# Altium schematic sheet Brush_Control_V2.0.0.SchDoc
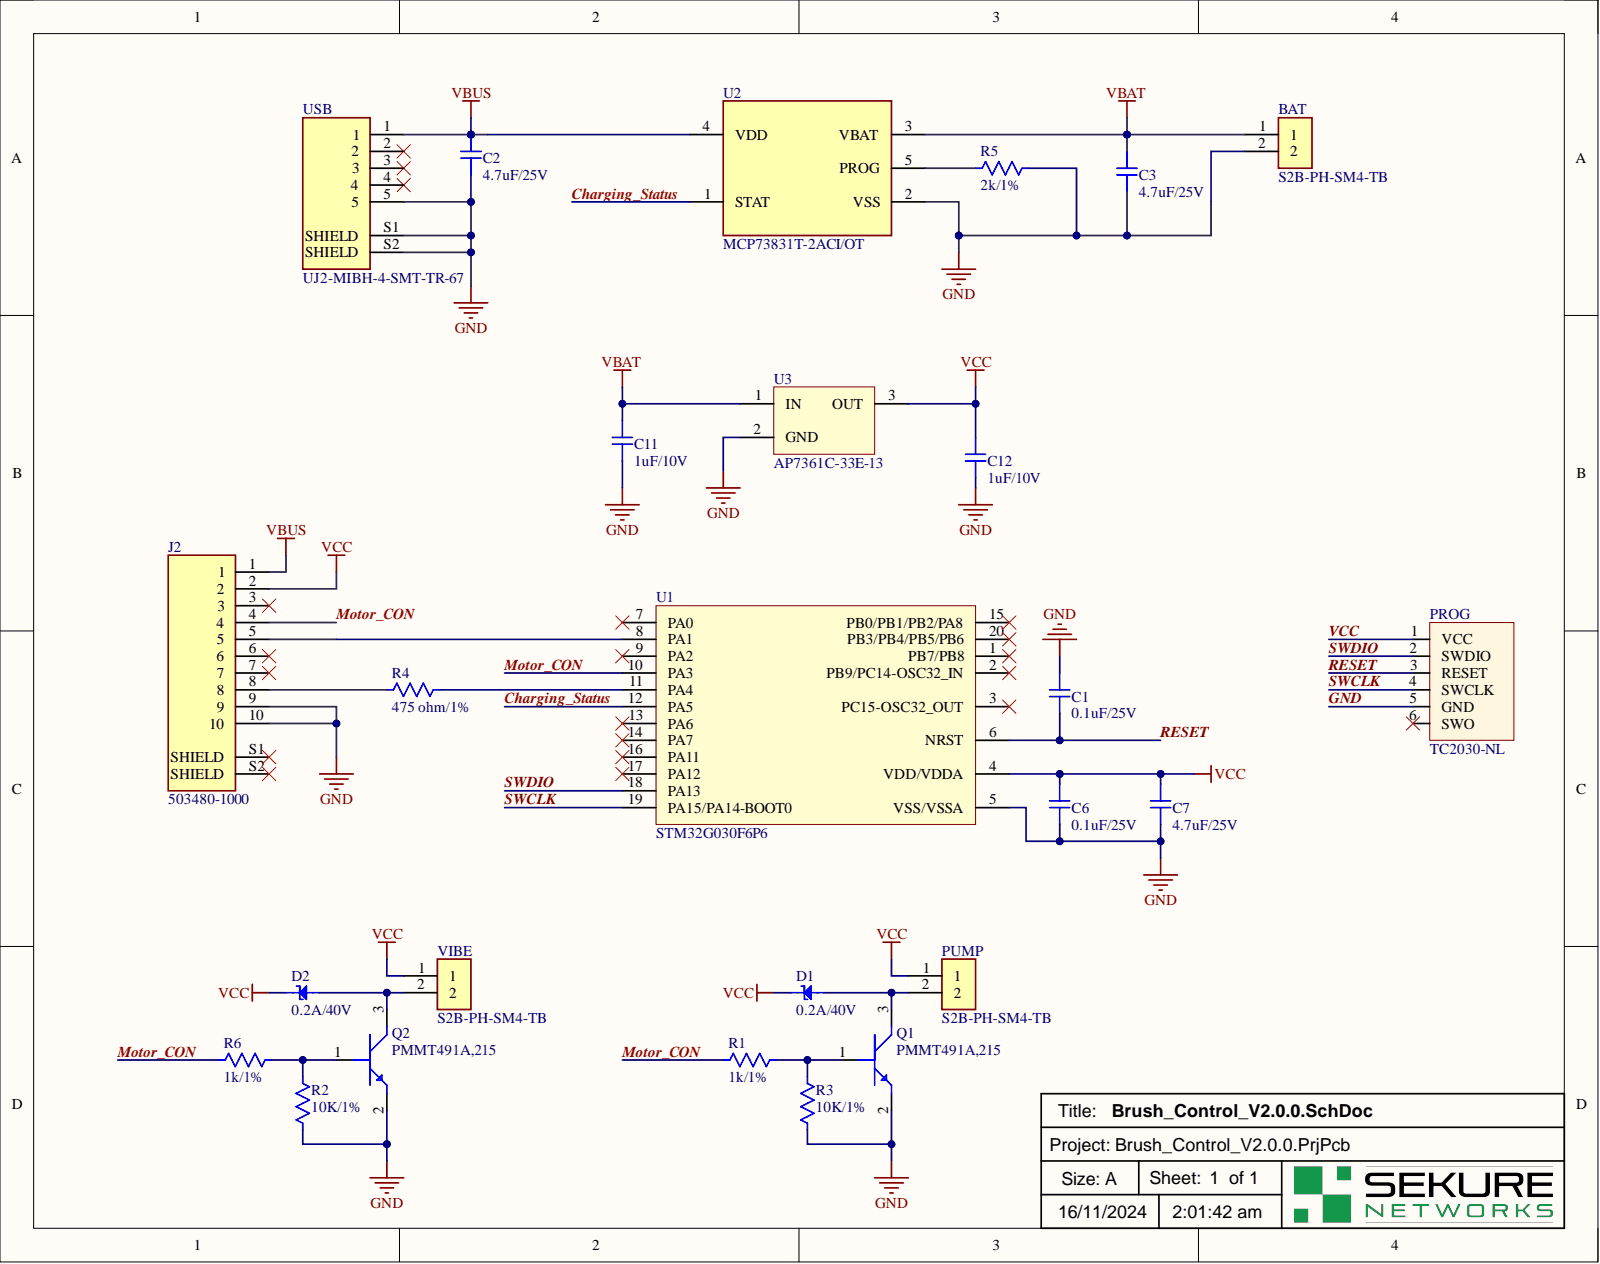
[diagram p0: Brush_Control_V2.0.0.SchDoc]

## Parts
BAT  value S2B-PH-SM4-TB  [S2B-PH-SM4-TB]  (hidden comment: Connector)
C1  value 0.1uF/25V  [CAP 0603]  (hidden comment: Ceramic Capacitor)
C2  value 4.7uF/25V  [CAP 0603]  (hidden comment: Ceramic Capacitor)
C3  value 4.7uF/25V  [CAP 0603]  (hidden comment: Ceramic Capacitor)
C6  value 0.1uF/25V  [CAP 0603]  (hidden comment: Ceramic Capacitor)
C7  value 4.7uF/25V  [CAP 0603]  (hidden comment: Ceramic Capacitor)
C11  value 1uF/10V  [CAP 0603]  (hidden comment: Ceramic Capacitor)
C12  value 1uF/10V  [CAP 0603]  (hidden comment: Ceramic Capacitor)
D1  value 0.2A/40V  [SOD323]  (hidden comment: Schottky Diode)
D2  value 0.2A/40V  [SOD323]  (hidden comment: Schottky Diode)
J2  value 503480-1000  [5034801000]  (hidden comment: Connector)
PROG  value TC2030-NL  [TC2030]  (hidden comment: Programming Connector)
PUMP  value S2B-PH-SM4-TB  [S2B-PH-SM4-TB]  (hidden comment: Connector)
Q1  value PMMT491A,215  [SOT23]  (hidden comment: Transistor)
Q2  value PMMT491A,215  [SOT23]  (hidden comment: Transistor)
R1  value 1k/1%  [RES 0603]  (hidden comment: Resistor)
R2  value 10K/1%  [RES 0603]  (hidden comment: Resistor)
R3  value 10K/1%  [RES 0603]  (hidden comment: Resistor)
R4  value 475 ohm/1%  [RES 0603]  (hidden comment: Resistor)
R5  value 2k/1%  [RES 0603]  (hidden comment: Resistor)
R6  value 1k/1%  [RES 0603]  (hidden comment: Resistor)
U1  value STM32G030F6P6  [TSSOP20]  (hidden comment: Microcontroller)
U2  value MCP73831T-2ACI/OT  [SOT23-5]  (hidden comment: IC)
U3  value AP7361C-33E-13  [SOT-223]  (hidden comment: Regulator)
USB  value UJ2-MIBH-4-SMT-TR-67  [UJ2-MIBH-4-SMT-TR-67]  (hidden comment: Connector)
VIBE  value S2B-PH-SM4-TB  [S2B-PH-SM4-TB]  (hidden comment: Connector)

## Nets
VBAT: BAT.1 C3.1 C11.1 U2.3(VBAT) U3.1
VBUS: C2.1 J2.1 U2.4(VDD) USB.1
Charging_Status: U1.12(PA5) U2.1(STAT)
GND: BAT.2 C1.2 C2.2 C3.2 C6.2 C7.2 C11.2 C12.1 J2.9 J2.10 PROG.5(GND) Q1.2 Q2.2 R2.2 R3.2 R5.2 U1.5(VSS/VSSA) U2.2(VSS) U3.2 USB.5 USB.S1(SHIELD) USB.S2(SHIELD)
Motor_CON: J2.4 R1.1 R6.1 U1.10(PA3)
RESET: C1.1 PROG.3(RESET) U1.6(NRST)
SWCLK: PROG.4(SWCLK) U1.19(PA15/PA14-BOOT0)
SWDIO: PROG.2(SWDIO) U1.18(PA13)
VCC: C6.1 C7.1 C12.2 D1.1 D2.1 J2.2 PROG.1(VCC) PUMP.1 U1.4(VDD/VDDA) U3.3 VIBE.1
NetD1_2: D1.2 PUMP.2 Q1.3
NetD2_2: D2.2 Q2.3 VIBE.2
NetJ2_5: J2.5 U1.8(PA1)
NetJ2_8: J2.8 R4.1
NetQ1_1: Q1.1 R1.2 R3.1
NetQ2_1: Q2.1 R2.1 R6.2
NetR4_2: R4.2 U1.11(PA4)
NetR5_1: R5.1 U2.5(PROG)

Not connected: J2 3 6 7 S1(SHIELD) S2(SHIELD); PROG 6(SWO); U1 1(PB7/PB8) 2(PB9/PC14-OSC32_IN) 3(PC15-OSC32_OUT) 7(PA0) 9(PA2) 13(PA6) 14(PA7) 15(PB0/PB1/PB2/PA8) 16(PA11) 17(PA12) 20(PB3/PB4/PB5/PB6); USB 2 3 4

## Library data of the parts
BAT PUMP VIBE = S2B-PH-SM4-TB: Header S2B-PH-SM4-TB, 2.00mm, 2-contacts, side entry, SMT, /1000/reel; Manufacturer: JST; Component Kind: Standard; DigiKey: 455-S2B-PH-SM4-TBCT-ND; Library Name: Connectors.IntLib; P/N: S2B-PH-SM4-TB; Pricing: 1=0.69, 10=0.521, 100=0.3977, 500=0.33232 (USD); RoHS: Yes; Stock: 64727; Supplier: Digikey
C1 C6 = CL10B104KA8NNNC: Multilayer Ceramic Capacitor, 0.1 uF, 25 V, ± 10%, X7R, 0603 [1608 Metric]; Manufacturer: Samsung; Material: 4241ConductorMaterial; Voltage: 25V; Case Code (Imperial): 0603; Case Code (Metric): 1608; Case/Package: 0603; Category: Ceramic Capacitors; Component Kind: Standard; ComponentLink1Description: https://datasheet.ciiva.com/25805/cl10b104ka8nnnc-25805120.pdf?src-supplier=Digikey; ComponentLink1URL: https://datasheet.ciiva.com/25805/cl10b104ka8nnnc-25805120.pdf?src-supplier=Digikey; ComponentLink2Description: https://datasheet.ciiva.com/26838/getdatasheetpartid-354050-26838409.pdf?src-supplier=Verical; ComponentLink2URL: https://datasheet.ciiva.com/26838/getdatasheetpartid-354050-26838409.pdf?src-supplier=Verical; Depth: 0.8mm; Dielectric: X7R; DigiKey: 1276-1006-1-ND; Height: 0.8mm; Lead Free: Lead Free; Length: 1.6mm; Library Name: Ceramic Capacitors.IntLib; Max Operating Temperature: 125°C; Min Operating Temperature: -55°C; Mounting Technology: Surface Mount; P/N: CL10B104KA8NNNC; Package Quantity: 1; Packaging: Tape and Reel; Pricing: 1=0.1, 10=0.024, 50=0.0136, 100=0.0105, 500=0.00792, 1000=0.00622 (USD); Radiation Hardening: No; RoHS: Yes; RoHS Compliant: Yes; Series: CL; Stock: 708971; Supplier: Digikey; Thickness: 0.035inch; Tolerance: 10%; Voltage Rating: 25V; Voltage Rating (DC): 25V; Width: 0.031inch
C2 C3 C7 = CL10A475MA8NQNC: Ceramic Capacitor, Multilayer, Ceramic, 25V, 20% +Tol, 20% -Tol, X5R, 15% TC, 4.7uF, Surface Mount, 0603; Manufacturer: Samsung; Multilayer: Yes; Capacitor Type: Ceramic; Case/Package: 0603; Category: Ceramic Capacitors; Component Kind: Standard; ComponentLink1Description: https://datasheet.ciiva.com:443/pdfs/VipMasterIC/IC/SEMS/SEMS-S-A0008384515/SEMS-S-A0008873310-1.pdf?src-supplier=IHS+Markit; ComponentLink1URL: https://datasheet.ciiva.com:443/pdfs/VipMasterIC/IC/SEMS/SEMS-S-A0008384515/SEMS-S-A0008873310-1.pdf?src-supplier=IHS+Markit; ComponentLink2Description: https://datasheet.ciiva.com/25787/cl10a475ma8nqnc-25787184.pdf?src-supplier=Digi-Key; ComponentLink2URL: https://datasheet.ciiva.com/25787/cl10a475ma8nqnc-25787184.pdf?src-supplier=Digi-Key; ComponentLink3Description: https://datasheet.ciiva.com/16437/cl10a475ma8nqnc-16437322.pdf?src-supplier=Future+Electronics; ComponentLink3URL: https://datasheet.ciiva.com/16437/cl10a475ma8nqnc-16437322.pdf?src-supplier=Future+Electronics; Dielectric Material: Ceramic; DigiKey: 1276-1903-1-ND; JESD-609 Code: e3; Library Name: Ceramic Capacitors.IntLib; Max Operating Temperature: 85°C; Min Operating Temperature: -55°C; Mounting Technology: Surface Mount; P/N: CL10A475MA8NQNC; Package Shape: Rectangular; Packaging: Tape and Reel; Pins: 2; Pricing: 1=0.27, 10=0.187, 50=0.1244, 100=0.1058, 500=0.07466, 1000=0.06533 (USD); Rated DC Voltage (URdc): 25V; Reach SVHC Compliant: Yes; RoHS: Yes; RoHS Compliant: Yes; Stock: 1889613; Supplier: Digi-Key; Temperature Characteristics Code: X5R; Terminal Finish: Nickel; Termination: Wraparound; Tolerance  (fill in as +-): 20%; Tolerance (fill in as +-): 20%
C11 C12 = CL10B105KP8NNNC: Multilayer Ceramic Capacitor, 1 uF, 10 V, ± 10%, X7R, 0603 [1608 Metric]; Manufacturer: Samsung; Material: 4241ConductorMaterial; Voltage Rating: 10V; Case Code (Imperial): 0603; Case Code (Metric): 1608; Case/Package: 0603; Category: Ceramic Capacitors; Component Kind: Standard; Construction: Flat; Depth: 0.8mm; Dielectric: X7R; DigiKey: 1276-1946-1-ND; Height: 0.8mm; Lead Free: Lead Free; Length: 1.6mm; Library Name: Ceramic Capacitors.IntLib; Max Operating Temperature: 125°C; Min Operating Temperature: -55°C; Mounting Technology: Surface Mount; P/N: CL10B105KP8NNNC; Package Quantity: 1; Packaging: Tape and Reel; Pricing: 1=0.1, 10=0.026, 50=0.0146, 100=0.0114, 500=0.00858, 1000=0.00674 (USD); Radiation Hardening: No; RoHS: Yes; RoHS Compliant: Yes; Series: CL; Stock: 7012743; Supplier: Digikey; Thickness: 0.035inch; Tolerance: 10%; Voltage Rating (DC): 10V; Width: 0.031inch
D1 D2 = BAT54HT1G: Small Signal Schottky Diode, Single, 30 V, 200 mA, 800 mV, 600 mA, 150 C ;RoHS Compliant: Yes; Manufacturer 1: ON Semiconductor / Fairchild; Average Rectified Current: 200mA; Capacitance: 10pF; Forward Voltage: 800mV; Max Forward Surge Current (Ifsm): 600mA; Max Repetitive Reverse Voltage (Vrrm): 30V; Max Reverse Leakage Current: 2uA; Polarity: Standard; Power Dissipation: 200mW; Recovery Time: 5ns; Component Kind: Standard; ComponentLink1Description: https://datasheet.ciiva.com/4714/bat54ht1-d-72881-4714706.pdf?src-supplier=Mouser; ComponentLink1URL: https://datasheet.ciiva.com/4714/bat54ht1-d-72881-4714706.pdf?src-supplier=Mouser; ComponentLink2Description: https://datasheet.ciiva.com/30479/0900766b811a63a9-30479442.pdf?src-supplier=RSComponents; ComponentLink2URL: https://datasheet.ciiva.com/30479/0900766b811a63a9-30479442.pdf?src-supplier=RSComponents; ComponentLink3Description: https://datasheet.ciiva.com/34301/806549-34301562.pdf?src-supplier=Jameco; ComponentLink3URL: https://datasheet.ciiva.com/34301/806549-34301562.pdf?src-supplier=Jameco; ComponentLink4Description: https://datasheet.ciiva.com/1240/1747983-1240762.pdf?src-supplier=Newark; ComponentLink4URL: https://datasheet.ciiva.com/1240/1747983-1240762.pdf?src-supplier=Newark; ComponentLink5Description: https://datasheet.ciiva.com/4452/bat54ht1g-104602-4452889.pdf?src-supplier=Mouser; ComponentLink5URL: https://datasheet.ciiva.com/4452/bat54ht1g-104602-4452889.pdf?src-supplier=Mouser; ComponentLink6Description: https://datasheet.ciiva.com/910/bat54ht1g-910497.pdf?src-supplier=Digi-Key; ComponentLink6URL: https://datasheet.ciiva.com/910/bat54ht1g-910497.pdf?src-supplier=Digi-Key; ComponentLink7Description: https://datasheet.ciiva.com/1180/bat54ht1-d-1180252.pdf?src-supplier=Element14; ComponentLink7URL: https://datasheet.ciiva.com/1180/bat54ht1-d-1180252.pdf?src-supplier=Element14; ComponentLink8Description: https://datasheet.ciiva.com/1180/bat54ht1-d-1180251.pdf?src-supplier=Element14; ComponentLink8URL: https://datasheet.ciiva.com/1180/bat54ht1-d-1180251.pdf?src-supplier=Element14; ComponentLink9Description: https://datasheet.ciiva.com/46429/1727620-46429206.pdf?src-supplier=Element14; ComponentLink9URL: https://datasheet.ciiva.com/46429/1727620-46429206.pdf?src-supplier=Element14; ComponentLink10Description: https://datasheet.ciiva.com/8294/bat54ht1-d-8294645.pdf?src-supplier=Avnet; ComponentLink10URL: https://datasheet.ciiva.com/8294/bat54ht1-d-8294645.pdf?src-supplier=Avnet; ComponentLink11Description: https://datasheet.ciiva.com/915/bat54ht1-d-915445.pdf?src-supplier=Digi-Key; ComponentLink11URL: https://datasheet.ciiva.com/915/bat54ht1-d-915445.pdf?src-supplier=Digi-Key; ComponentLink12Description: https://datasheet.ciiva.com/915/bat54ht1-d-915444.pdf?src-supplier=Digi-Key; ComponentLink12URL: https://datasheet.ciiva.com/915/bat54ht1-d-915444.pdf?src-supplier=Digi-Key; ComponentLink13Description: https://datasheet.ciiva.com/915/bat54ht1-d-915443.pdf?src-supplier=Digi-Key; ComponentLink13URL: https://datasheet.ciiva.com/915/bat54ht1-d-915443.pdf?src-supplier=Digi-Key; ComponentLink14Description: https://datasheet.ciiva.com/910/bat54ht1g-910499.pdf?src-supplier=Digi-Key; ComponentLink14URL: https://datasheet.ciiva.com/910/bat54ht1g-910499.pdf?src-supplier=Digi-Key; ComponentLink15Description: https://datasheet.ciiva.com/910/bat54ht1g-910498.pdf?src-supplier=Digi-Key; ComponentLink15URL: https://datasheet.ciiva.com/910/bat54ht1g-910498.pdf?src-supplier=Digi-Key; ComponentLink16Description: https://datasheet.ciiva.com/8303/bat54ht1g-8303645.pdf?src-supplier=Avnet; ComponentLink16URL: https://datasheet.ciiva.com/8303/bat54ht1g-8303645.pdf?src-supplier=Avnet; ComponentLink17Description: https://datasheet.ciiva.com/17020/bat54ht1g-17020445.pdf?src-supplier=Future+Electronics; ComponentLink17URL: https://datasheet.ciiva.com/17020/bat54ht1g-17020445.pdf?src-supplier=Future+Electronics; ComponentLink18Description: https://datasheet.ciiva.com/48686/001262925-da-01-en-diode-schottky-30v-bat54ht1g-sod-323-fsc-48686266.pdf?src-supplier=Conrad; ComponentLink18URL: https://datasheet.ciiva.com/48686/001262925-da-01-en-diode-schottky-30v-bat54ht1g-sod-323-fsc-48686266.pdf?src-supplier=Conrad; Contact Plating: Tin,Matte; Current Rating: 200mA; DigiKey: BAT54HT1GOSCT-ND; Element Configuration: Single; Forward Current: 200mA; Height: 1mm; Lead Free: Lead Free; Length: 1.8mm; Library Name: Diode.IntLib; Max Operating Temperature: 150°C; Max Reverse Voltage (DC): 30V; Max Surge Current: 600mA; Min Operating Temperature: -55°C; Mount: Surface Mount; Number of Pins: 2; Output Current: 200mA; P/N: BAT54HT1G; Package Quantity: 3000; Packaging: Tape and Reel; Peak Non-Repetitive Surge Current: 600mA; Peak Reverse Current: 2uA; Pricing 1: 1=0.20000, 10=0.18100, 25=0.17240, 100=0.07590, 500=0.05962, 1000=0.04140 (USD); Radiation Hardening: No; REACH SVHC: No SVHC; Reverse Recovery Time: 5ns; Reverse Voltage: 30V; RoHS: Yes; Stock 1: 227163; Supplier 1: Digi-Key; Voltage Rating (DC): 30V; Width: 1.35mm
J2 = 503480-1000: FFC/FPC Connector, 10 Contact(s), 1 Row(s), Female, Right Angle, 0.02 inch Pitch, Surface Mount Terminal, Locking; Manufacturer: Molex; Category: FFC / FPC; Component Kind: Standard; ComponentLink1Description: https://datasheet.ciiva.com/26916/getdatasheetpartid-735332-26916946.pdf?src-supplier=Verical; ComponentLink1URL: https://datasheet.ciiva.com/26916/getdatasheetpartid-735332-26916946.pdf?src-supplier=Verical; ComponentLink2Description: https://datasheet.ciiva.com/5137/5034801000-ffc-fpc-connectors-179433-5137762.pdf?src-supplier=Mouser; ComponentLink2URL: https://datasheet.ciiva.com/5137/5034801000-ffc-fpc-connectors-179433-5137762.pdf?src-supplier=Mouser; ComponentLink3Description: https://datasheet.ciiva.com/671/5034801000-sd-671179.pdf?src-supplier=Digikey; ComponentLink3URL: https://datasheet.ciiva.com/671/5034801000-sd-671179.pdf?src-supplier=Digikey; DigiKey: WM1389CT-ND; Library Name: Connectors.IntLib; P/N: 503480-1000; Pricing: 1=1.14, 10=0.851, 25=0.7652, 50=0.709, 100=0.6593, 250=0.60228, 500=0.56502, 1000=0.53218 (USD); RoHS: Yes; Stock: 16792; Supplier: Digikey
PROG = TC2030: “No Legs” 6-pin Plug-of-Nails™ Cable fitted with a 6-pin 0.1″ pitch ribbon connector.; Assembly: DNP; Component Kind: Standard; Library Name: Programming Connectors.IntLib
Q1 Q2 = PMMT491A,215: Small Signal Bipolar Transistor, 1A I(C), 40V V(BR)CEO, 1-Element, NPN, Silicon, TO-236AB; Manufacturer: Nexperia; DC Current Gain-Min (hFE): 200; Frequency: 150MHz; Number of Elements: 1; Package Body Material: Plastic; Time @ Peak Reflow Temperature-Max (s): 30s; Transistor Application: SWITCHING; Category: BJTs; Collector-emitter Voltage-Max: 40V; Component Kind: Standard; ComponentLink1Description: https://datasheet.ciiva.com:443/pdfs/VipMasterIC/IC/NEXP/NEXP-S-A0002881467/NEXP-S-A0002882567-1.pdf?src-supplier=IHS+Markit; ComponentLink1URL: https://datasheet.ciiva.com:443/pdfs/VipMasterIC/IC/NEXP/NEXP-S-A0002881467/NEXP-S-A0002882567-1.pdf?src-supplier=IHS+Markit; ComponentLink2Description: https://datasheet.octopart.com/PMMT491A%2C215-Nexperia-datasheet-10337295.pdf?src-supplier=Farnell; ComponentLink2URL: https://datasheet.octopart.com/PMMT491A%2C215-Nexperia-datasheet-10337295.pdf?src-supplier=Farnell; ComponentLink3Description: https://datasheet.octopart.com/PMMT491A%2C215-Nexperia-datasheet-87582102.pdf?src-supplier=TME; ComponentLink3URL: https://datasheet.octopart.com/PMMT491A%2C215-Nexperia-datasheet-87582102.pdf?src-supplier=TME; DigiKey: 1727-5220-1-ND; JEDEC-95 Code: TO-236AB; JESD-30 Code: R-PDSO-G3; JESD-609 Code: e3; Library Name: Transistor.IntLib; Max Collector Current: 1A; Moisture Sensitivity Level: 1; P/N: PMMT491A,215; Package Shape: Rectangular; Package Style: SMALL OUTLINEMeter; Peak Reflow Temperature (Cel): 260°C; Pins: 3; Polarity/Channel Type: NPN; Pricing: 1=0.34, 10=0.239, 100=0.1207, 500=0.09844, 1000=0.07303 (USD); Reach SVHC Compliant: Yes; RoHS: Yes; RoHS Compliant: Yes; Stock: 36431; Supplier: Digikey; Surface Mount: Yes; Terminal Finish: Tin; Terminal Form: Gull Wing; Terminal Position: DUAL; Transistor Element Material: SILICON
R1 R6 = CRCW06031K00FKEA: RES SMD 1 kohm 1% Thick Film, 100 mW; Manufacturer: Vishay Dale; Max Power Dissipation: 100mW; Resistance: 1kR; Temperature Coefficient: 100ppm/°C; Voltage Rating: 75V; Case Code (Imperial): 0603; Case Code (Metric): 1608; Case/Package: 0603; Component Kind: Standard; ComponentLink1Description: https://datasheet.ciiva.com/11808/0900766b80d98afc-11808625.pdf?src-supplier=RSComponents; ComponentLink1URL: https://datasheet.ciiva.com/11808/0900766b80d98afc-11808625.pdf?src-supplier=RSComponents; ComponentLink2Description: https://datasheet.ciiva.com/2465/dcrcwe3-2465536.pdf?src-supplier=Digi-Key; ComponentLink2URL: https://datasheet.ciiva.com/2465/dcrcwe3-2465536.pdf?src-supplier=Digi-Key; ComponentLink3Description: https://datasheet.ciiva.com/8336/dcrcwe3-8336822.pdf?src-supplier=Avnet; ComponentLink3URL: https://datasheet.ciiva.com/8336/dcrcwe3-8336822.pdf?src-supplier=Avnet; ComponentLink4Description: https://datasheet.ciiva.com/16515/dcrcwe3-16515078.pdf?src-supplier=Avnet+Asia; ComponentLink4URL: https://datasheet.ciiva.com/16515/dcrcwe3-16515078.pdf?src-supplier=Avnet+Asia; ComponentLink5Description: https://datasheet.ciiva.com/46441/1720486-46441622.pdf?src-supplier=Element14; ComponentLink5URL: https://datasheet.ciiva.com/46441/1720486-46441622.pdf?src-supplier=Element14; ComponentLink6Description: https://datasheet.ciiva.com/26316/fld-crcw-e3-data-en-26316551.pdf?src-supplier=Distrelec; ComponentLink6URL: https://datasheet.ciiva.com/26316/fld-crcw-e3-data-en-26316551.pdf?src-supplier=Distrelec; ComponentLink7Description: https://datasheet.ciiva.com/46441/1720486-46441623.pdf?src-supplier=Element14; ComponentLink7URL: https://datasheet.ciiva.com/46441/1720486-46441623.pdf?src-supplier=Element14; ComponentLink8Description: https://datasheet.ciiva.com/46385/1697337-46385497.pdf?src-supplier=Element14; ComponentLink8URL: https://datasheet.ciiva.com/46385/1697337-46385497.pdf?src-supplier=Element14; ComponentLink9Description: https://datasheet.ciiva.com/3257/dcrcwe3-3257132.pdf?src-supplier=Avnet; ComponentLink9URL: https://datasheet.ciiva.com/3257/dcrcwe3-3257132.pdf?src-supplier=Avnet; ComponentLink10Description: https://datasheet.ciiva.com/16199/dcrcwe3-16199053.pdf?src-supplier=Future+Electronics; ComponentLink10URL: https://datasheet.ciiva.com/16199/dcrcwe3-16199053.pdf?src-supplier=Future+Electronics; ComponentLink11Description: https://datasheet.ciiva.com/2465/dcrcwe3-2465534.pdf?src-supplier=Digi-Key; ComponentLink11URL: https://datasheet.ciiva.com/2465/dcrcwe3-2465534.pdf?src-supplier=Digi-Key; ComponentLink12Description: https://datasheet.ciiva.com/16893/dcrcwe3-16893742.pdf?src-supplier=Avnet+Europe; ComponentLink12URL: https://datasheet.ciiva.com/16893/dcrcwe3-16893742.pdf?src-supplier=Avnet+Europe; ComponentLink13Description: https://datasheet.ciiva.com/2465/dcrcwe3-2465535.pdf?src-supplier=Digi-Key; ComponentLink13URL: https://datasheet.ciiva.com/2465/dcrcwe3-2465535.pdf?src-supplier=Digi-Key; Composition: Thick Film; Contact Plating: Tin; Depth: 0.85mm; DigiKey: 541-1.00KHCT-ND; Features: Automotive AEC-Q200; Height: 0.45mm; Lead Free: Lead Free; Length: 1.55mm; Library Name: Resistors.IntLib; Max Operating Temperature: 155°C; Military Standard: Not; Min Operating Temperature: -55°C; Mount: Surface Mount; Number of Pins: 2; Number of Terminations: 2; P/N: CRCW06031K00FKEA; Package Quantity: 10; Packaging: Tape and Reel; Power Rating: 100mW; Pricing 1: 1=0.10000, 10=0.03400, 100=0.01390, 1000=0.00623, 2500=0.00540 (USD); Radiation Hardening: No; REACH SVHC: Unknown; RoHS: Yes; Stock 1: 1070064; Supplier 1: Digi-Key; Termination: SMD/SMT; Tolerance: 1%; Voltage Rating (DC): 75V; Weight: 7.1E-05oz; Width: 0.033inch
R2 R3 = RC0603FR-0710KL: RES SMD 10K OHM 1% 1/10W 0603; Manufacturer: Yageo; Power Rating: 100mW; Resistance: 10kR; Temperature Coefficient: 100ppm/°C; Voltage Rating: 75V; Voltage Rating (DC): 50V; Case Code (Imperial): 0603; Case Code (Metric): 1608; Case/Package: 0603; Component Kind: Standard; ComponentLink1Description: https://datasheet.ciiva.com/7660/38590-7660789.pdf?src-supplier=Element14; ComponentLink1URL: https://datasheet.ciiva.com/7660/38590-7660789.pdf?src-supplier=Element14; ComponentLink2Description: https://datasheet.ciiva.com/15189/getdatasheetpartid-409289-15189591.pdf?src-supplier=Verical; ComponentLink2URL: https://datasheet.ciiva.com/15189/getdatasheetpartid-409289-15189591.pdf?src-supplier=Verical; ComponentLink3Description: https://datasheet.ciiva.com/46440/1868787-46440891.pdf?src-supplier=Element14; ComponentLink3URL: https://datasheet.ciiva.com/46440/1868787-46440891.pdf?src-supplier=Element14; ComponentLink4Description: https://datasheet.ciiva.com/7983/443971-7983596.pdf?src-supplier=Element14; ComponentLink4URL: https://datasheet.ciiva.com/7983/443971-7983596.pdf?src-supplier=Element14; ComponentLink5Description: https://datasheet.ciiva.com/7746/1738695-7746239.pdf?src-supplier=Element14; ComponentLink5URL: https://datasheet.ciiva.com/7746/1738695-7746239.pdf?src-supplier=Element14; ComponentLink6Description: https://datasheet.ciiva.com/22747/443971-22747519.pdf?src-supplier=Element14; ComponentLink6URL: https://datasheet.ciiva.com/22747/443971-22747519.pdf?src-supplier=Element14; Composition: Thick Film; Depth: 0.8mm; DigiKey: 311-10.0KHRDKR-ND; Height: 0.45mm; Lead Free: Lead Free; Lead Pitch: 0.3mm; Length: 1.6mm; Library Name: Resistors.IntLib; Max Operating Temperature: 155°C; Military Standard: Not; Min Operating Temperature: -55°C; Number of Pins: 2; P/N: RC0603FR-0710KL; Packaging: Tape and Reel; Pricing 1: 1=0.10000, 10=0.02300, 100=0.00940, 1000=0.00421, 2500=0.00366 (USD); REACH SVHC: No SVHC; RoHS: Yes; Stock 1: 55833360; Supplier 1: Digi-Key; Termination: SMD/SMT; Tolerance: 1%; Weight: 7.1E-05oz; Width: 0.8mm
R4 = CRCW0603475RFKEAHP: RES SMD 475 OHM 1% 1/4W 0603; Manufacturer: Vishay Dale; Max Power Dissipation: 250mW; Resistance: 475R; Temperature Coefficient: 100ppm/°C; Case Code (Imperial): 0603; Case Code (Metric): 1608; Case/Package: 0603; Component Kind: Standard; ComponentLink1Description: https://datasheet.ciiva.com/2527/crcwhpe3-2527281.pdf?src-supplier=Digi-Key; ComponentLink1URL: https://datasheet.ciiva.com/2527/crcwhpe3-2527281.pdf?src-supplier=Digi-Key; ComponentLink2Description: https://datasheet.ciiva.com/2527/crcwhpe3-2527280.pdf?src-supplier=Digi-Key; ComponentLink2URL: https://datasheet.ciiva.com/2527/crcwhpe3-2527280.pdf?src-supplier=Digi-Key; ComponentLink3Description: https://datasheet.ciiva.com/8933/crcwhpe3-8933851.pdf?src-supplier=Avnet; ComponentLink3URL: https://datasheet.ciiva.com/8933/crcwhpe3-8933851.pdf?src-supplier=Avnet; ComponentLink4Description: https://datasheet.ciiva.com/2527/crcwhpe3-2527279.pdf?src-supplier=Digi-Key; ComponentLink4URL: https://datasheet.ciiva.com/2527/crcwhpe3-2527279.pdf?src-supplier=Digi-Key; Contact Plating: Tin; DigiKey: CRCW0603475RFKEAHPCT-ND; Features: Automotive AEC-Q200; Height: 0.022inch; Lead Free: Lead Free; Length: 0.063inch; Library Name: Resistors.IntLib; Max Operating Temperature: 155°C; Min Operating Temperature: -55°C; Number of Terminations: 2; P/N: CRCW0603475RFKEAHP; Package Quantity: 10; Packaging: Tape and Reel; Pricing 1: 1=0.17000, 10=0.14800, 100=0.05790, 1000=0.02422, 2500=0.02220 (USD); Radiation Hardening: No; Resistor Type: Thick Film; RoHS: Yes; RoHS Compliant: Yes; Series: CRCW-HP; Stock 1: 3719; Supplier 1: Digi-Key; Tolerance: 1%; Width: 0.033inch
R5 = RC0603FR-072KL: YAGEO - RC0603FR-072KL - RES, THICK FILM, 2K, 1%, 0.1W, 0603; Max Power Dissipation: 100mW; Resistance: 2kR; Temperature Coefficient: 100ppm/°C; Voltage Rating: 50V; Working Voltage: 75V; Case Code (Imperial): 0603; Case Code (Metric): 1608; Case/Package: 0603; Category: Chip SMD Resistors; Component Kind: Standard; ComponentLink1Description: https://datasheet.ciiva.com/11808/0900766b80f96399-11808134.pdf?src-supplier=RSComponents; ComponentLink1URL: https://datasheet.ciiva.com/11808/0900766b80f96399-11808134.pdf?src-supplier=RSComponents; ComponentLink2Description: https://datasheet.ciiva.com/22747/443971-22747526.pdf?src-supplier=Element14; ComponentLink2URL: https://datasheet.ciiva.com/22747/443971-22747526.pdf?src-supplier=Element14; ComponentLink3Description: https://datasheet.ciiva.com/2453/pyu-r-int-thick-7-2453913.pdf?src-supplier=Digi-Key; ComponentLink3URL: https://datasheet.ciiva.com/2453/pyu-r-int-thick-7-2453913.pdf?src-supplier=Digi-Key; ComponentLink4Description: https://datasheet.ciiva.com/12555/rc0603-272842-12555257.pdf?src-supplier=Mouser; ComponentLink4URL: https://datasheet.ciiva.com/12555/rc0603-272842-12555257.pdf?src-supplier=Mouser; ComponentLink5Description: https://datasheet.ciiva.com/2453/pyu-r-int-thick-7-2453914.pdf?src-supplier=Digi-Key; ComponentLink5URL: https://datasheet.ciiva.com/2453/pyu-r-int-thick-7-2453914.pdf?src-supplier=Digi-Key; ComponentLink6Description: https://datasheet.ciiva.com/2453/pyu-r-int-thick-7-2453915.pdf?src-supplier=Digi-Key; ComponentLink6URL: https://datasheet.ciiva.com/2453/pyu-r-int-thick-7-2453915.pdf?src-supplier=Digi-Key; Construction: Rectangular; Depth: 0.8mm; DigiKey: 311-2.00KHRCT-ND; Features: Moisture Resistant; Height: 0.45mm; Lead Free: Lead Free; Length: 1.6mm; Library Name: Resistors.IntLib; Manufacturer: Yageo; Max Operating Temperature: 155°C; Military Standard: Not; Min Operating Temperature: -55°C; Number of Pins: 2; Number of Terminations: 2; P/N: RC0603FR-072KL; Package Quantity: 1; Packaging: Tape and Reel; Power Rating: 100mW; Pricing 1: 1=0.10000, 10=0.02400, 100=0.00970, 1000=0.00436, 2500=0.00378 (USD); REACH SVHC: No SVHC; Resistance Tolerance: 1%; Resistor Type: Thick Film; RoHS: Yes; RoHS Compliant: Yes; Series: RC; Stock 1: 1157228; Supplier 1: Digi-Key; Technology: THICK FILM; Termination: SMD/SMT; Tolerance: 1%; Voltage Rating (DC): 50V; Width: 0.031inch
U1 = STM32G030F6P6: IC MCU 32BIT 32KB FLASH 20TSSOP; Manufacturer: STMicroelectronics; Category: Microcontrollers; Component Kind: Standard; ComponentLink1Description: https://datasheet.ciiva.com:443/pdfs/VipMasterIC/IC/SGST/SGST-S-A0009406778/SGST-S-A0009406778-1.pdf?src-supplier=IHS+Markit; ComponentLink1URL: https://datasheet.ciiva.com:443/pdfs/VipMasterIC/IC/SGST/SGST-S-A0009406778/SGST-S-A0009406778-1.pdf?src-supplier=IHS+Markit; ComponentLink2Description: http://www.farnell.com/datasheets/2882477.pdf?src-supplier=Farnell; ComponentLink2URL: http://www.farnell.com/datasheets/2882477.pdf?src-supplier=Farnell; ComponentLink3Description: https://www.st.com/resource/en/datasheet/stm32g030c6.pdf?src-supplier=Digikey; ComponentLink3URL: https://www.st.com/resource/en/datasheet/stm32g030c6.pdf?src-supplier=Digikey; ComponentLink4Description: https://datasheet.octopart.com/STM32G030F6P6-STMicroelectronics-datasheet-137692524.pdf?src-supplier=TME; ComponentLink4URL: https://datasheet.octopart.com/STM32G030F6P6-STMicroelectronics-datasheet-137692524.pdf?src-supplier=TME; DigiKey: 497-STM32G030F6P6-ND; Library Name: Microcontrollers.IntLib; P/N: STM32G030F6P6; Pricing: 1=1.13, 10=1.015, 74=0.95743, 148=0.81574, 296=0.76595, 444=0.67426 (USD); RoHS: Yes; RoHS Compliant: Yes; Stock: 93286; Supplier: Digikey
U2 = MCP73831T-2ACI/OT: Power Supply Support Circuit, Adjustable, 1 Channel, PDSO5; Manufacturer: Microchip; Adjustable Threshold: Yes; Channels: 1; Max Supply Voltage: 6V; Min Supply Voltage: 3.75V; Nominal Supply Voltage: 5.2V; Package Body Material: Plastic; Package Equivalence Code: TSOP5/6,.11,37; Power Supplies: 3.3/5V; Qualification Status: Not Qualified; Supply Current-Max (Isup): 1.5mA; Time @ Peak Reflow Temperature-Max (s): 40s; Case/Package: LSSOP; Category: Battery Management; Component Kind: Standard; ComponentLink1Description: https://datasheet.ciiva.com:443/pdfs/VipMasterIC/IC/MCHP/MCHPS05673/MCHPS05673-1.pdf?src-supplier=IHS+Markit; ComponentLink1URL: https://datasheet.ciiva.com:443/pdfs/VipMasterIC/IC/MCHP/MCHPS05673/MCHPS05673-1.pdf?src-supplier=IHS+Markit; ComponentLink2Description: https://datasheet.ciiva.com/22828/0900766b80d2b53a-22828876.pdf?src-supplier=Rs; ComponentLink2URL: https://datasheet.ciiva.com/22828/0900766b80d2b53a-22828876.pdf?src-supplier=Rs; ComponentLink3Description: https://datasheet.ciiva.com/26344/651521-da-01-en-contr-mcp73831t-2aci-ot-sot-23-5-mcp-26344458.pdf?src-supplier=Conrad; ComponentLink3URL: https://datasheet.ciiva.com/26344/651521-da-01-en-contr-mcp73831t-2aci-ot-sot-23-5-mcp-26344458.pdf?src-supplier=Conrad; ComponentLink4Description: https://datasheet.ciiva.com/1137/630369-1137803.pdf?src-supplier=Element14; ComponentLink4URL: https://datasheet.ciiva.com/1137/630369-1137803.pdf?src-supplier=Element14; ComponentLink5Description: https://datasheet.ciiva.com/46385/630369-46385324.pdf?src-supplier=Element14; ComponentLink5URL: https://datasheet.ciiva.com/46385/630369-46385324.pdf?src-supplier=Element14; ComponentLink6Description: https://datasheet.ciiva.com/16286/filehandler-aspxddocname-en025112-16286957.pdf?src-supplier=Future+Electronics; ComponentLink6URL: https://datasheet.ciiva.com/16286/filehandler-aspxddocname-en025112-16286957.pdf?src-supplier=Future+Electronics; ComponentLink7Description: https://datasheet.ciiva.com/1931/21984e-1931954.pdf?src-supplier=Digikey; ComponentLink7URL: https://datasheet.ciiva.com/1931/21984e-1931954.pdf?src-supplier=Digikey; ComponentLink8Description: https://datasheet.ciiva.com/1931/21984e-1931955.pdf?src-supplier=Digikey; ComponentLink8URL: https://datasheet.ciiva.com/1931/21984e-1931955.pdf?src-supplier=Digikey; ComponentLink9Description: https://datasheet.ciiva.com/1931/21984e-1931953.pdf?src-supplier=Digikey; ComponentLink9URL: https://datasheet.ciiva.com/1931/21984e-1931953.pdf?src-supplier=Digikey; ComponentLink10Description: https://datasheet.ciiva.com/28626/630369-28626439.pdf?src-supplier=Element14; ComponentLink10URL: https://datasheet.ciiva.com/28626/630369-28626439.pdf?src-supplier=Element14; ComponentLink11Description: https://datasheet.ciiva.com/1144/630369-1144461.pdf?src-supplier=Newark; ComponentLink11URL: https://datasheet.ciiva.com/1144/630369-1144461.pdf?src-supplier=Newark; DigiKey: MCP73831T-2ACI/OTCT-ND; Height - Seated (Max): 1.45mm; JESD-30 Code: R-PDSO-G5; JESD-609 Code: e3; Length: 2.95mm; Library Name: Regulators.IntLib; Max Operating Temperature: 85°C; Min Operating Temperature: -40°C; Moisture Sensitivity Level: 1; Number of Channels: 1; P/N: MCP73831T-2ACI/OT; Package Shape: Rectangular; Package Style: SMALL OUTLINE, LOW PROFILE, SHRINK PITCHMeter; Peak Reflow Temperature (Cel): 260°C; Pins: 5; Pricing: 1=0.76, 25=0.63, 100=0.6125 (USD); Reach SVHC Compliant: Yes; RoHS: Yes; RoHS Compliant: Yes; Stock: 94329; Supplier: Digikey; Surface Mount: Yes; Temperature Grade: INDUSTRIAL; Terminal Finish: Tin; Terminal Form: Gull Wing; Terminal Pitch: 0.95mm; Terminal Position: DUAL; Throw Configuration: POWER SUPPLY SUPPORT CIRCUIT; Width: 1.65mm
U3 = AP7361C-33E-13: Fixed Positive LDO Regulator, 3.3V, 0.15V Dropout, PDSO3; Manufacturer: Diodes; Channels: 1; Dropout Voltage1-Max: 150mV; Max Supply Voltage: 6V; Min Input Voltage: 4.3V; Output Current1-Max: 1A; Output Voltage1-Max: 3.333V; Output Voltage1-Min: 3.267V; Package Body Material: Plastic; Regulator Type: FIXED POSITIVE SINGLE OUTPUT LDO REGULATOR; Case/Package: SOP; Category: TVS Diodes; Component Kind: Standard; ComponentLink1Description: https://datasheet.ciiva.com:443/pdfs/VipMasterIC/IC/DIOD/DIOD-S-A0004145196/DIOD-S-A0004145196-1.pdf?src-supplier=IHS+Markit; ComponentLink1URL: https://datasheet.ciiva.com:443/pdfs/VipMasterIC/IC/DIOD/DIOD-S-A0004145196/DIOD-S-A0004145196-1.pdf?src-supplier=IHS+Markit; ComponentLink2Description: http://www.mouser.com/ds/2/115/AP7361C-775034.pdf?src-supplier=Mouser; ComponentLink2URL: http://www.mouser.com/ds/2/115/AP7361C-775034.pdf?src-supplier=Mouser; ComponentLink3Description: https://datasheet.octopart.com/AP7361C-33E-13-Diodes-Inc.-datasheet-101745716.pdf?src-supplier=Element14; ComponentLink3URL: https://datasheet.octopart.com/AP7361C-33E-13-Diodes-Inc.-datasheet-101745716.pdf?src-supplier=Element14; ComponentLink4Description: https://datasheet.octopart.com/AP7361C-33E-13-Diodes-Inc.-datasheet-101745716.pdf?src-supplier=Future+Electronics; ComponentLink4URL: https://datasheet.octopart.com/AP7361C-33E-13-Diodes-Inc.-datasheet-101745716.pdf?src-supplier=Future+Electronics; ComponentLink5Description: https://www.diodes.com/assets/Datasheets/AP7361C.pdf?src-supplier=Digikey; ComponentLink5URL: https://www.diodes.com/assets/Datasheets/AP7361C.pdf?src-supplier=Digikey; ComponentLink6Description: https://datasheet.octopart.com/AP7361C-33E-13-Diodes-Inc.-datasheet-158996477.pdf?src-supplier=SHENGYU+ELECTRONICS; ComponentLink6URL: https://datasheet.octopart.com/AP7361C-33E-13-Diodes-Inc.-datasheet-158996477.pdf?src-supplier=SHENGYU+ELECTRONICS; DigiKey: AP7361C-33E-13DICT-ND; Height - Seated (Max): 1.8mm; JESD-30 Code: R-PDSO-G3; JESD-609 Code: e3; Length: 6.5mm; Library Name: Regulators.IntLib; Operating Temperature, TJ-Max: 150°C; Operating Temperature, TJ-Min: -40°C; Output Voltage1-Nom: 3.3V; P/N: AP7361C-33E-13; Package Shape: Rectangular; Package Style: SMALL OUTLINEMeter; Pins: 3; Pricing: 1=0.91, 10=0.567, 25=0.4748, 100=0.3699, 250=0.31836, 500=0.28676, 1000=0.26041 (USD); Reach SVHC Compliant: Yes; RoHS: Yes; RoHS Compliant: Yes; Stock: 16932; Supplier: Digikey; Surface Mount: Yes; Terminal Finish: Tin; Terminal Form: Gull Wing; Terminal Pitch: 2.3mm; Terminal Position: DUAL; Width: 3.5mm
USB = UJ2-MIBH-4-SMT-TR-67: USB Connector, 5 Contact(s), Female, Right Angle, Surface Mount Terminal, Receptacle; Manufacturer: CUI Devices; Category: USB Connectors; Component Kind: Standard; ComponentLink1Description: https://www.cuidevices.com/product/resource/digikeypdf/uj2-mibh-4-smt-tr-67.pdf?src-supplier=Digikey; ComponentLink1URL: https://www.cuidevices.com/product/resource/digikeypdf/uj2-mibh-4-smt-tr-67.pdf?src-supplier=Digikey; ComponentLink2Description: https://datasheet.octopart.com/UJ2-MIBH-4-SMT-TR-67-CUI-Devices-datasheet-154834583.pdf?src-supplier=Electro+Sonic; ComponentLink2URL: https://datasheet.octopart.com/UJ2-MIBH-4-SMT-TR-67-CUI-Devices-datasheet-154834583.pdf?src-supplier=Electro+Sonic; DigiKey: 102-4970-1-ND; Library Name: Connectors.IntLib; P/N: UJ2-MIBH-4-SMT-TR-67; Pricing: 1=4.21, 10=3.219, 25=2.9012, 50=2.6858, 100=2.4899, 250=2.25836, 500=2.1021 (USD); RoHS: Yes; Stock: 31220; Supplier: Digikey
